# Altium schematic sheet DTU_PWR.SchDoc
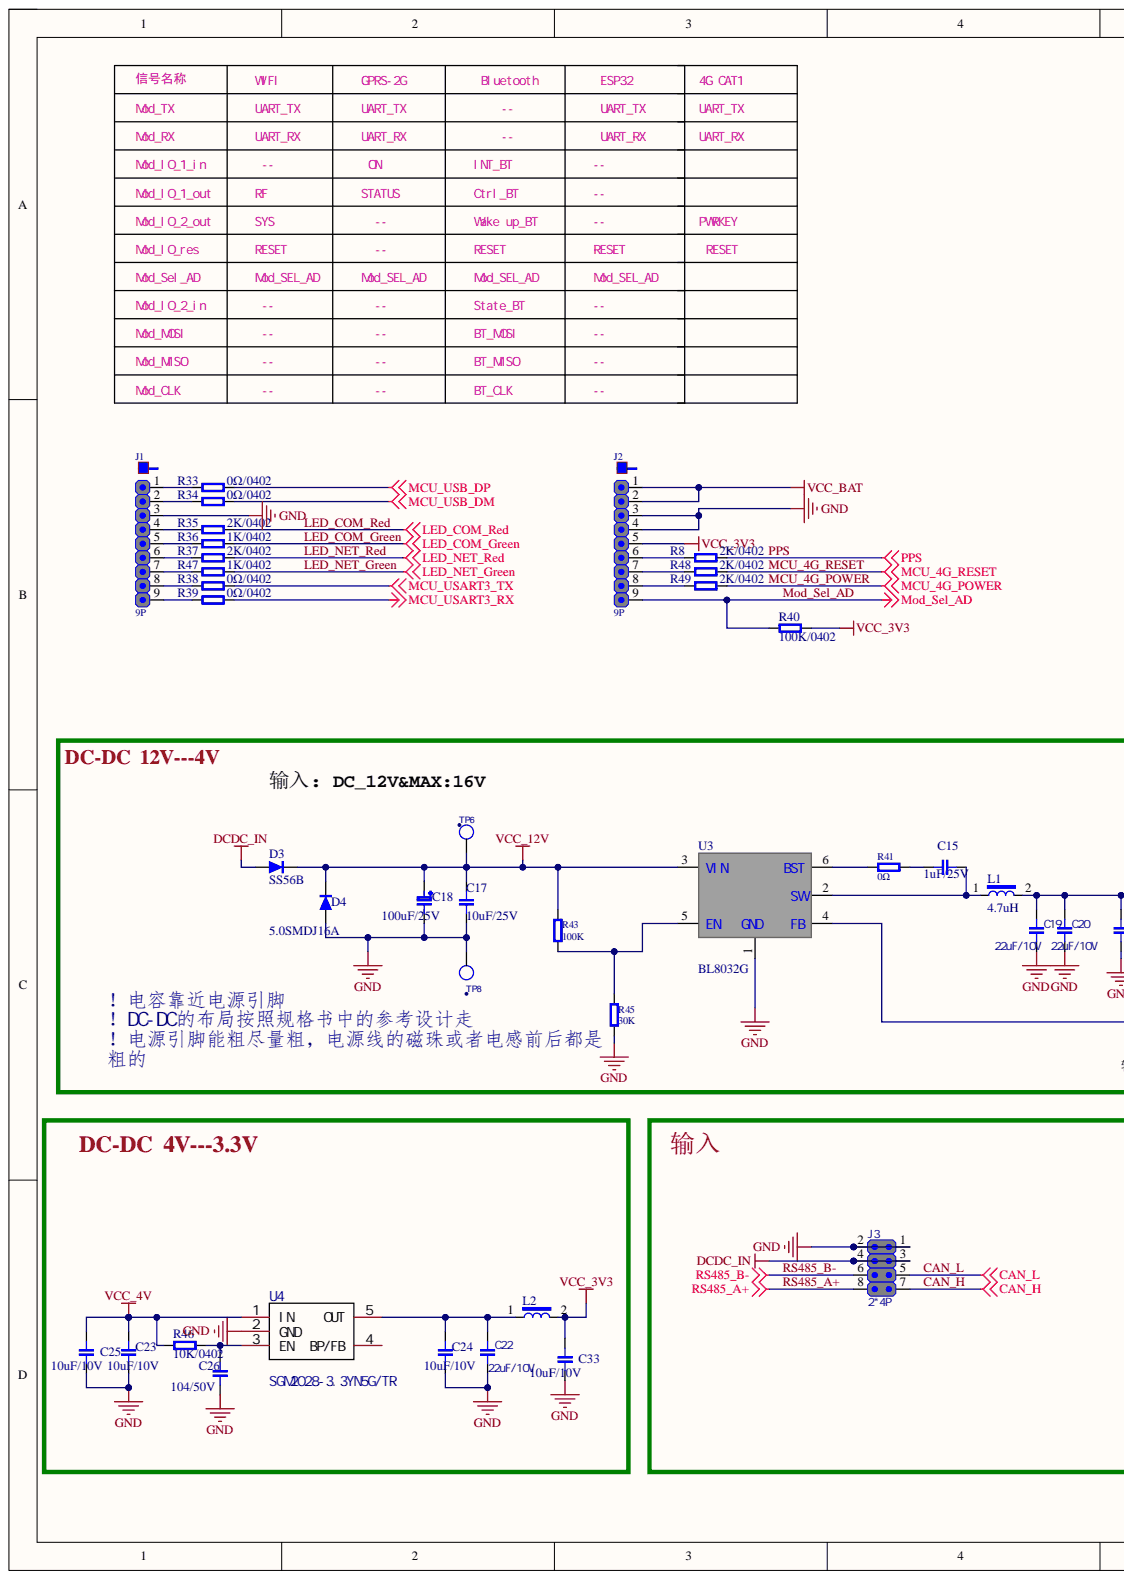
[diagram p0: DTU_PWR.SchDoc, left]
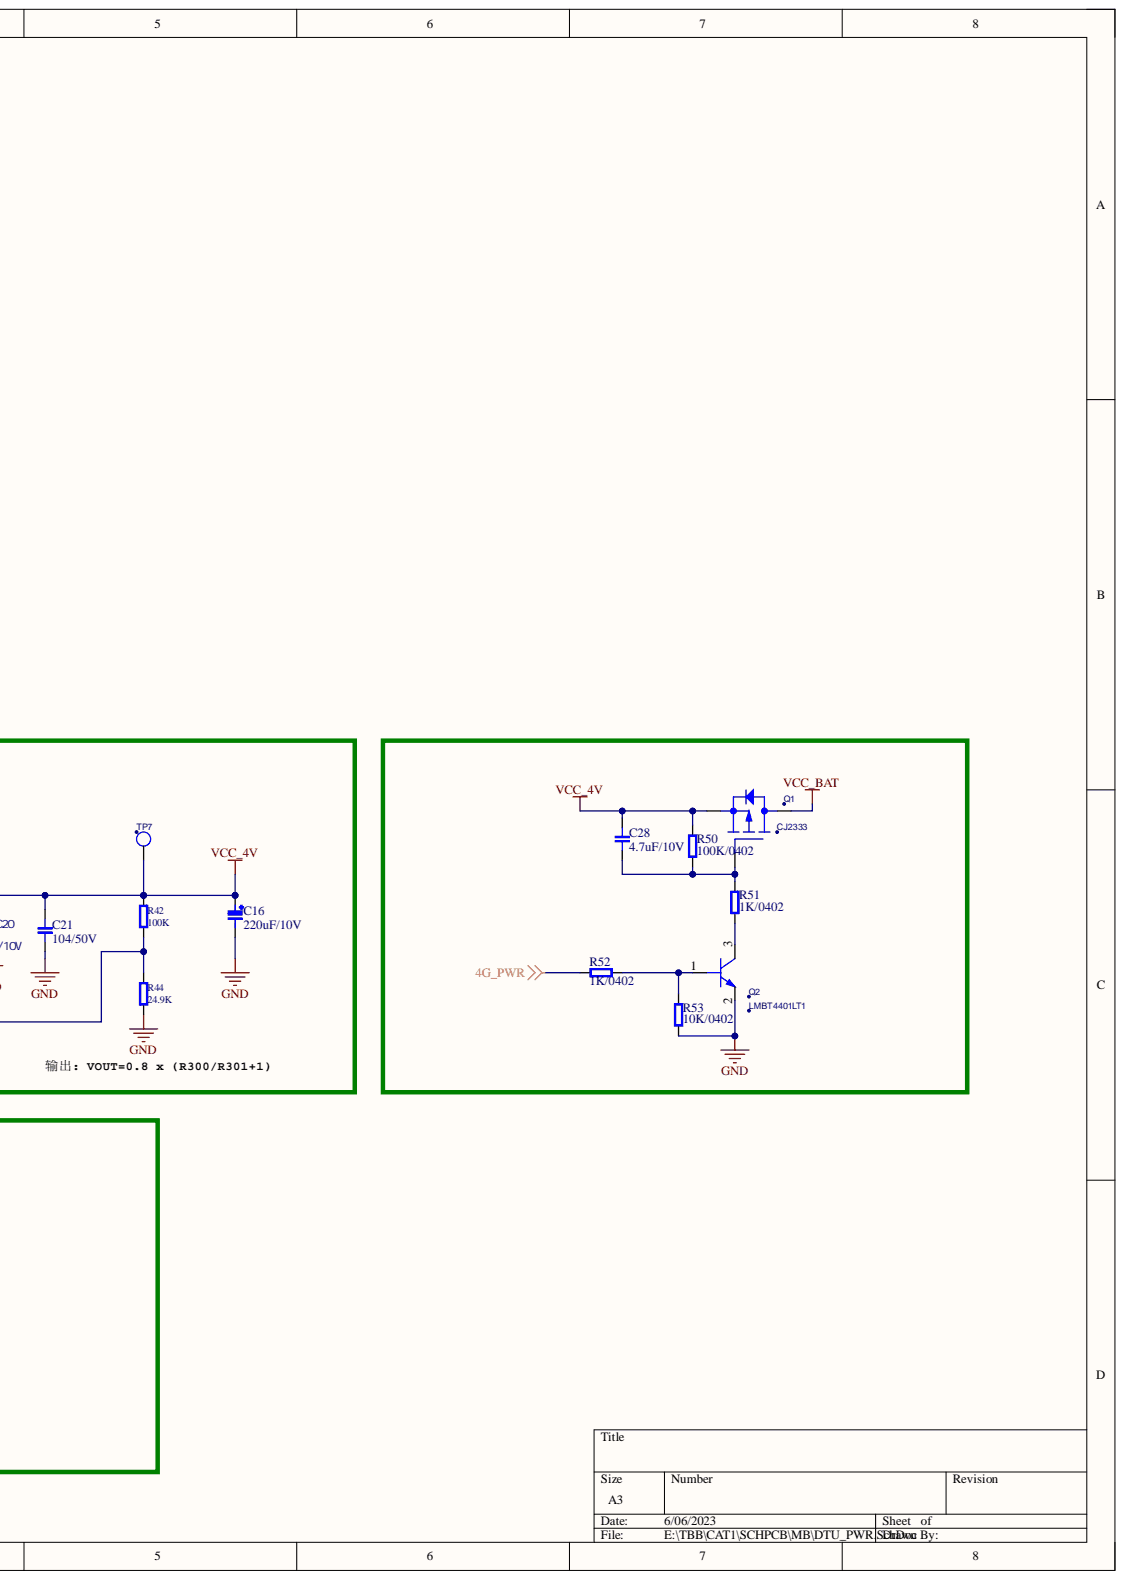
[diagram p1: DTU_PWR.SchDoc, right]

## Parts
C15  1uF/25V  [C0603-B]
C16  220uF/10V  [CDS-Φ6.3×5.7]
C17  10uF/25V  [C1206]
C18  100uF/25V  [CDS-Φ6.3×7.7]
C19  22uF/10V  [C0805]
C20  22uF/10V  [C0805]
C21  104/50V  [C0402]
C22  22uF/10V  [C0805]
C23  10uF/10V  [C0603-B]
C24  10uF/10V  [C0603-B]
C25  10uF/10V  [C0603-B]
C26  104/50V  [C0402]
C28  4.7uF/10V  [C0402]
C33  10uF/10V  [C0603-B]
D3  SS56B  [SMB]
D4  5.0SMDJ16A  [SMC]
J1  9P  [CN_1_9P_254_B]
J2  9P  [CN_1_9P_254_B]
J3  2*4P  [201R-2*4P-P2.0(2.7-4)]
L1  4.7uH  [7.0*7.0*5.5]
L2  100Ω，2A，100MHz  [L2012]  (value not drawn)
Q1  CJ2333  [SOT-23]
Q2  LMBT4401LT1  [SOT-23]
R8  2K/0402  [R0402]
R33  0Ω/0402  [R0402]
R34  0Ω/0402  [R0402]
R35  2K/0402  [R0402]
R36  1K/0402  [R0402]
R37  2K/0402  [R0402]
R38  0Ω/0402  [R0402]
R39  0Ω/0402  [R0402]
R40  100K/0402  [R0402]
R41  0Ω  [R0603]
R42  100K  [R0603]
R43  100K  [R0603]
R44  24.9K  [R0603]
R45  30K  [R0603]
R46  10K/0402  [R0402]
R47  1K/0402  [R0402]
R48  2K/0402  [R0402]
R49  2K/0402  [R0402]
R50  100K/0402  [R0402]
R51  1K/0402  [R0402]
R52  1K/0402  [R0402]
R53  10K/0402  [R0402]
TP6  T_POINT_R  [TP-SMD]  (value not drawn)
TP7  T_POINT_R  [TP-SMD]  (value not drawn)
TP8  T_POINT_R  [TP-SMD]  (value not drawn)
U3  BL8032G  [SOT-23-6]
U4  SGM2028-3.3YN5G/TR  [SOT-23-5]

## Nets
4G_PWR: R52.1
DCDC_IN: D3.1 J3.3 J3.4
GND: C16.2 C17.2 C18.2 C19.2 C20.2 C21.2 C22.2 C23.2 C24.2 C25.2 C26.2 C33.2 D4.1 J1.3 J2.3 J2.4 J3.1 J3.2 Q2.2 R44.2 R45.1 R53.1 TP8.1 U3.1 U4.2(GND)
MCU_USART3_RX: R39.2
MCU_USART3_TX: R38.2
MCU_USB_DM: R34.2
MCU_USB_DP: R33.2
VCC_3V3: C33.1 J2.5 L2.2 R40.1
VCC_4V: C16.1 C19.1 C20.1 C21.1 C23.1 C25.1 C28.2 L1.2 Q1.2 R42.2 R46.1 R50.1 TP7.1 U4.1(IN)
VCC_12V: C17.1 C18.1 D3.2 D4.2 R43.2 TP6.1 U3.3(VIN)
VCC_BAT: J2.1 J2.2 Q1.3
CAN_H: J3.7
CAN_L: J3.5
LED_COM_Green: R36.2
LED_COM_Red: R35.2
LED_NET_Green: R47.2
LED_NET_Red: R37.2
MCU_4G_POWER: R49.2
MCU_4G_RESET: R48.2
Mod_Sel_AD: J2.9 R40.2
PPS: R8.2
RS485_A+: J3.8
RS485_B-: J3.6
NetC15_1: C15.1 L1.1 U3.2(SW)
NetC15_2: C15.2 R41.2
NetC22_1: C22.1 C24.1 L2.1 U4.5(OUT)
NetC26_1: C26.1 R46.2 U4.3(EN)
NetC28_1: C28.1 Q1.1 R50.2 R51.2
NetJ1_1: J1.1 R33.1
NetJ1_2: J1.2 R34.1
NetJ1_4: J1.4 R35.1
NetJ1_5: J1.5 R36.1
NetJ1_6: J1.6 R37.1
NetJ1_7: J1.7 R47.1
NetJ1_8: J1.8 R38.1
NetJ1_9: J1.9 R39.1
NetJ2_6: J2.6 R8.1
NetJ2_7: J2.7 R48.1
NetJ2_8: J2.8 R49.1
NetQ2_1: Q2.1 R52.2 R53.2
NetQ2_3: Q2.3 R51.1
NetR41_1: R41.1 U3.6(BST)
NetR42_1: R42.1 R44.1 U3.4(FB)
NetR43_1: R43.1 R45.2 U3.5(EN)

Not connected: U4 4(BP/FB)

## Library data of the parts
C15 = C0603_1uF_25V: 贴片电容,X7R,0603,105,1uF,25V,±10%,-55℃～125℃; Part_number: 10206-0035; Component Kind: Standard; Library Name: Capacity.SchLib
C16 = 220uF/10V: 贴片电解电容,,220uF,10V,±20%,6.3*5.7mm -55-105℃ LELON; Component Kind: Standard; Library Name: Capacity.SchLib
C17 = C1206_10uF_25V: 贴片电容,1206,X7R,106,25V,10uF,±10%,-55℃～125℃; Part_number: 10206-0063; Component Kind: Standard; Library Name: Capacity.SchLib
C18 = 100uF/25V: 贴片电解电容,VEJ101M1ETR-0607,100uF,25V,±20%,6.3*7.7mm; Part_number: 10206-0095; Component Kind: Standard; Library Name: Capacity.SchLib
C19 C20 C22 = C0805_22uF_10V: 贴片电容,0805,226,22uF,10V,±20%; Part_number: 10206-0094; Component Kind: Standard; Library Name: Capacity.SchLib
C21 C26 = C0402_100nF_50V: 贴片电容,X7R,0402,104,100nF,50V,±10%,-55℃～125℃; Part_number: 10206-0123; Component Kind: Standard; Library Name: Capacity.SchLib
C23-C25 C33 = C0603_10uF_10V: 贴片电容,X5R,0603,106,10uF,10V,±20%; Part_number: 10206-0081; Component Kind: Standard; Library Name: Capacity.SchLib
C28 = C0402_4.7uF_10V: 贴片电容,X5R,0402,4.7uF,10V,±10%; Part_number: 10206-0112; Component Kind: Standard; Library Name: Capacity.SchLib
D3 = SS56B: VRRM=60V,IF,AV=5.0A; Part_number: 10501-0042; Component Kind: Standard; Library Name: DIODE.IntLib
D4 = 5.0SMDJ16A: 单向，瞬态电压抑制二极管，Vrwm=16V; Part_number: 10501-0046; Component Kind: Standard; Library Name: DIODE.IntLib
J1 J2 = HEADER 9: 端子,单排母座,2.54*8.5-1*9P/180°/r/L9.8,Odia; Part_number: 10904-0093; Component Kind: Standard; Library Name: MianBoard.SCHLIB
J3 = 201R-2*4P-P2.0: 插针,双排,2.0*5.6*2*4P,Odia; Part_number: 10904-0094; Component Kind: Standard; Library Name: CONNECTOR.IntLib
L1 = SLF7055T-4R7N3R1-3PF: 贴片电感,SLF7055T-4R7N3R1-3PF,4.7uH,±30%,7*7*5.5mm; Part_number: 10303-0013; Component Kind: Standard; Library Name: INDUCTANCE.IntLib
L2 = HCB2012KF-800T30: 贴片磁珠,MPZ2012S101AT000,100Ω@100MHz,2A,0805,TDK; Part_number: 10303-0015; Component Kind: Standard; Library Name: INDUCTANCE.IntLib
Q1 = PMOS_0: MOS管，CJ2333(S33)，SOT-23,CJ; Part_number: 10402-0049; Component Kind: Standard
Q2 = NPN: 贴片三极管,LMBT4401LT1,2X,SOT-23,NPNSilicon,40Vdc,600mAdc; Part_number: 10404-0001; Component Kind: Standard
R8 R35 R37 R48 R49 = R0402_2K: 贴片电阻,0402,2KΩ,1/16W,1%; Part_number: 10102-0309; Component Kind: Standard; Library Name: Resistance.SchLib
R33 R34 R38 R39 = R0402_0Ω: 贴片电阻,0402,0Ω,1/16W,±1%; Part_number: 10102-0253; Component Kind: Standard; Library Name: Resistance.SchLib
R36 R47 R51 R52 = R0402_1K: 贴片电阻,0402,1KΩ,1/16W,1%; Part_number: 10102-0276; Component Kind: Standard; Library Name: Resistance.SchLib
R40 R50 = R0402_100K: 贴片电阻,0402,100KΩ,1/16W,1%; Part_number: 10102-0310; Component Kind: Standard; Library Name: Resistance.SchLib
R41 = R0603_0Ω: 贴片电阻,0603,0Ω,1/10W,±1%; Part_number: 10102-0001; Component Kind: Standard; Library Name: Resistance.SchLib
R42 = R0603_100K: 贴片电阻,0603,100KΩ,1/10W,±1%; Part_number: 10102-0005; Component Kind: Standard; Library Name: Resistance.SchLib
R43 = R0603_17: 贴片电阻,0603,100KΩ,1/10W,±1%; Part_number: 10102-0005; Component Kind: Standard; Library Name: MB-EMS.SCHLIB
R44 = R0603_24.9K: 贴片电阻,0603,24.9KΩ,1/10W,±1%; Part_number: 10102-0034; Component Kind: Standard; Library Name: Resistance.SchLib
R45 = R0603_30K: 贴片电阻,0603,30KΩ,1/10W,±1%; Part_number: 10102-0043; Component Kind: Standard; Library Name: Resistance.SchLib
R46 R53 = R0402_10K: 贴片电阻,RTT02103JTH,0402,10K,5%,Ralec; Part_number: 10102-0279; Component Kind: Standard; Library Name: Resistance.SchLib
U3 = BL8032G: 贴片IC,BL8032CB6TR,SOT23-6,电源芯片,2A,-40℃-85℃,上海贝岭; Part_number: 10802-0239; Component Kind: Standard; Library Name: Q_IC.SchLib
U4 = SGM2028-3.3YN5G/TR: 最大输入电压:5.5V;压降(max):420mV @ 500mA;输出电压(可调):-;静态电流(Iq):-;输出类型:固定;输出电压(固定):3.3V;; Manufacturer: SGMICRO(圣邦微); Add into BOM: yes; Number of Output Channels: 1; Output Configuration: 正; Ripple Rejection (PSRR): 77dB ~ 73dB(217Hz ~ 1kHz); Voltage - Input (Max): 5.5V; Voltage - Output (Fixed): 3.3V; Component Kind: Standard; Convert to PCB: yes; Current - Supply (Max): -; Datasheet: https://atta.szlcsc.com/upload/public/pdf/source/20170704/1499151376719.pdf; Device: SGM2028-3.3YN5G/TR; Enabling feet: -; JLCPCB Part Class: Extended Part; Manufacturer Part: SGM2028-3.3YN5G/TR; Name: SGM2028-3.3YN5G/TR; Operating Temperature: -40℃~85℃; Output Current (Max): 500mA; Output Type: 固定; Quiescent Current (Iq): -; Supplier: LCSC; Supplier Footprint: SOT-23-5; Supplier Part: C81088; Symbol: SGM2028-3.3YN5G/TR; Voltage - Output (adj): -; Voltage Dropout (Max): 420mV @ 500mA
TP6-TP8 = T_POINT_R: Component Kind: Standard
